# Altium schematic sheet [10] Ethernet.SchDoc("[10] Ethernet")
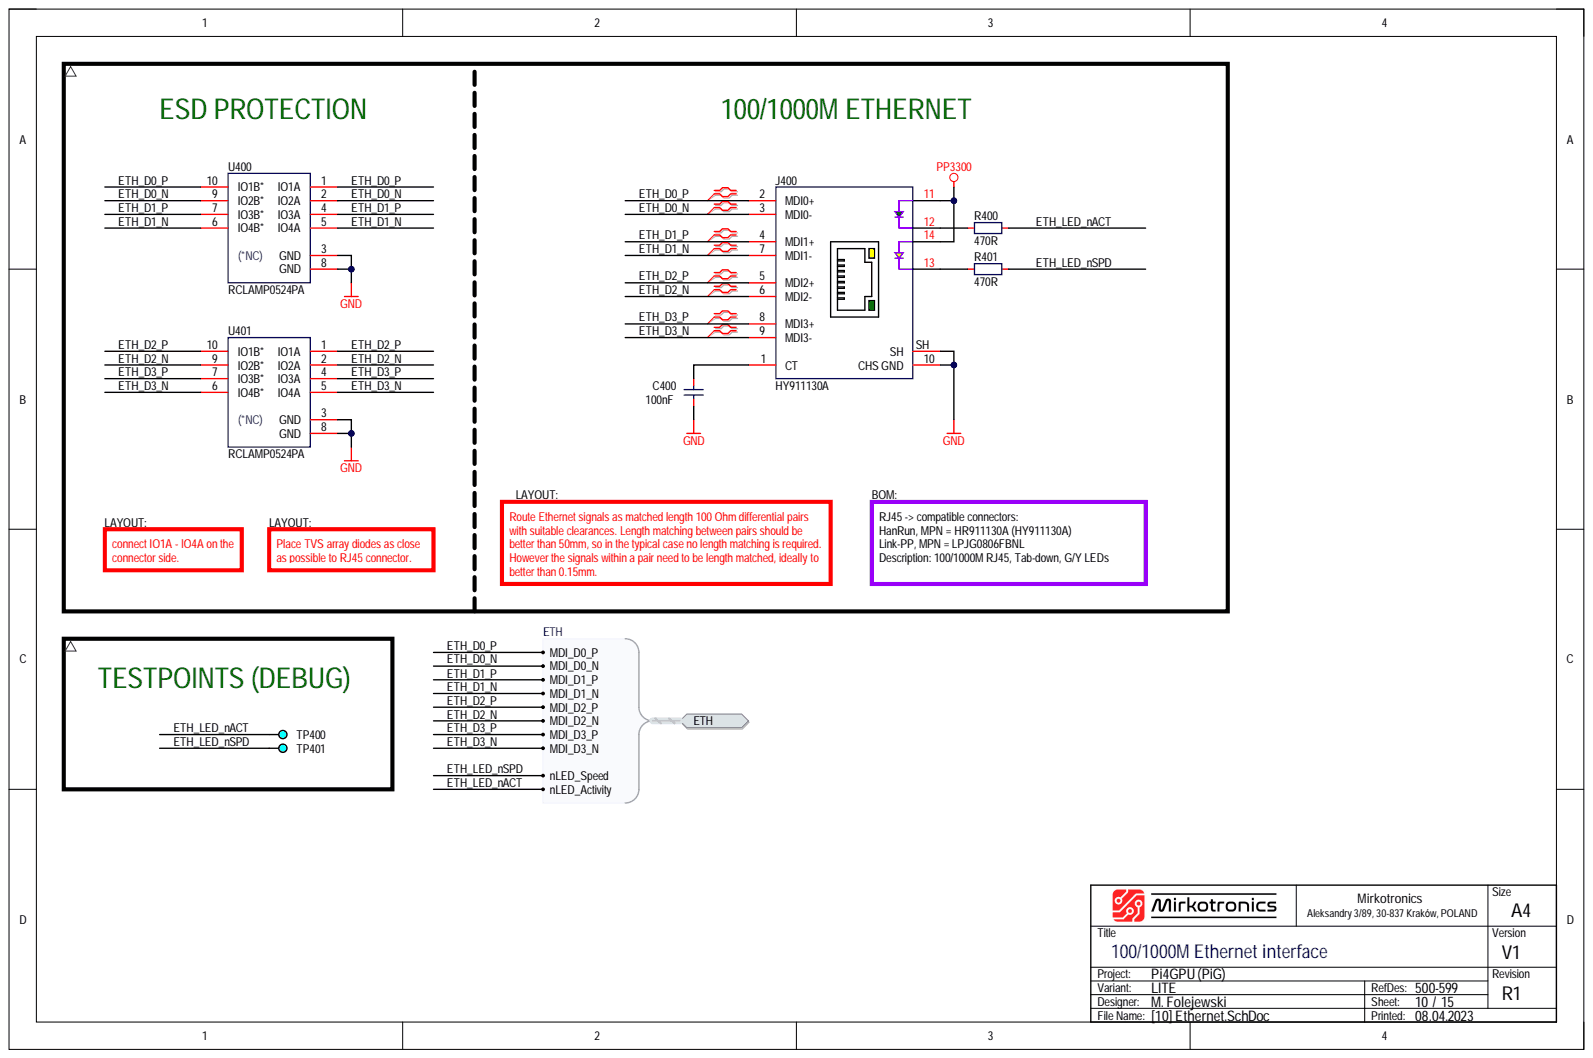
[diagram p0: [10] Ethernet.SchDoc]

## Parts
C400  100nF  [CAP_0603_R]
J400  HY911130A  [CON_WRT_7499110122]
R400  470R  [RES_0603_R]
R401  470R  [RES_0603_R]
TP400  DEBUG  [PCB_TP_DBG_50]  (value not drawn)  (no BOM)
TP401  DEBUG  [PCB_TP_DBG_50]  (value not drawn)  (no BOM)
U400  RCLAMP0524PA  [ICC_SLP2510P8_250X100X60_P50_R]
U401  RCLAMP0524PA  [ICC_SLP2510P8_250X100X60_P50_R]

## Ports
ETH, ETH.MDI_D0_N, ETH.MDI_D0_P, ETH.MDI_D1_N, ETH.MDI_D1_P, ETH.MDI_D2_N, ETH.MDI_D2_P, ETH.MDI_D3_N, ETH.MDI_D3_P, ETH.nLED_Activity, ETH.nLED_Speed

## Nets
GND: C400.1 J400.10(CHS_GND) J400.SH U400.3(GND) U400.8(GND) U401.3(GND) U401.8(GND)
PP3300: J400.11 J400.14
ETH_D0_N: J400.3(MDI0-) U400.2(IO2A) U400.9(IO2B*) ; port ETH
ETH_D0_P: J400.2(MDI0+) U400.1(IO1A) U400.10(IO1B*) ; port ETH
ETH_D1_N: J400.7(MDI1-) U400.5(IO4A) U400.6(IO4B*) ; port ETH
ETH_D1_P: J400.4(MDI1+) U400.4(IO3A) U400.7(IO3B*) ; port ETH
ETH_D2_N: J400.6(MDI2-) U401.2(IO2A) U401.9(IO2B*) ; port ETH
ETH_D2_P: J400.5(MDI2+) U401.1(IO1A) U401.10(IO1B*) ; port ETH
ETH_D3_N: J400.9(MDI3-) U401.5(IO4A) U401.6(IO4B*) ; port ETH
ETH_D3_P: J400.8(MDI3+) U401.4(IO3A) U401.7(IO3B*) ; port ETH
ETH_LED_nACT: R400.1 TP400.1 ; port ETH
ETH_LED_nSPD: R401.1 TP401.1 ; port ETH
NetC400_2: C400.2 J400.1(CT)
NetJ400_12: J400.12 R400.2
NetJ400_13: J400.13 R401.2

## Library data of the parts
C400 = CAP-0008: Capacitor; MLCC; 100nF; TBD; TBD; TBD; TBD; SMD; 0603 (1608);; Database Table Name: CAPACITOR$; Component Kind: Standard; Component Subtype: MLCC; Component Type: Capacitor; Manufacturer: TBD; Mounting Type: SMD; MPN: TBD; Package (Case): 0603 (1608); Supplier: ?; Value: 100nF; Library Name: MirFol_db_local.DbLib
J400 = CON_HAN_HY911130A: Connector; RJ45;; Manufacturer: HanRun; Component Kind: Standard; Component Subtype: RJ45; Component Type: Connector; Mounting Type: THT; MPN: HY911130A; Supplier: ?; Value: HY911130A; Library Name: MirFol_lib.SchLib
R400 R401 = RES-0042: Resistor; Thick Film; 470R; 1%; 100mW; 100PPM; 75V; Standard; SMD; 0603 (1608);; Manufacturer: Yageo Corporation; MPN: TBD; Database Table Name: RESISTOR$; Component Kind: Standard; Component Subtype: Thick Film; Component Type: Resistor; Mounting Type: SMD; Package (Case): 0603 (1608); Supplier: ?; Value: 470R; Library Name: MirFol_db_local.DbLib
TP400 TP401 = PCB_TP_DBG: PCB Marking; Test Point (Debug);; Component Subtype: -; Component Type: Testpoint; Mounting Type: -; Supplier: ?; Value: DEBUG; Library Name: MirFol_lib.SchLib
U400 U401 = ICC-0005: IC; TVS Arrays; ESD Protection; 4-Channel; 17kV Air; 12kV Contact; 0.3pF Capitance; TBD; SMD; TBD;; Manufacturer: Semtech Corporation; MPN: RCLAMP0524PATCT; Database Table Name: IC (MISC)$; Component Kind: Standard; Component Subtype: TVS Arrays; Component Type: IC; Mounting Type: SMD; Package (Case): TBD; Supplier: ?; Value: RCLAMP0524PA; Library Name: MirFol_db_local.DbLib
